# Altium schematic sheet ГРЛМ.468263.003_2 Э3.SchDoc
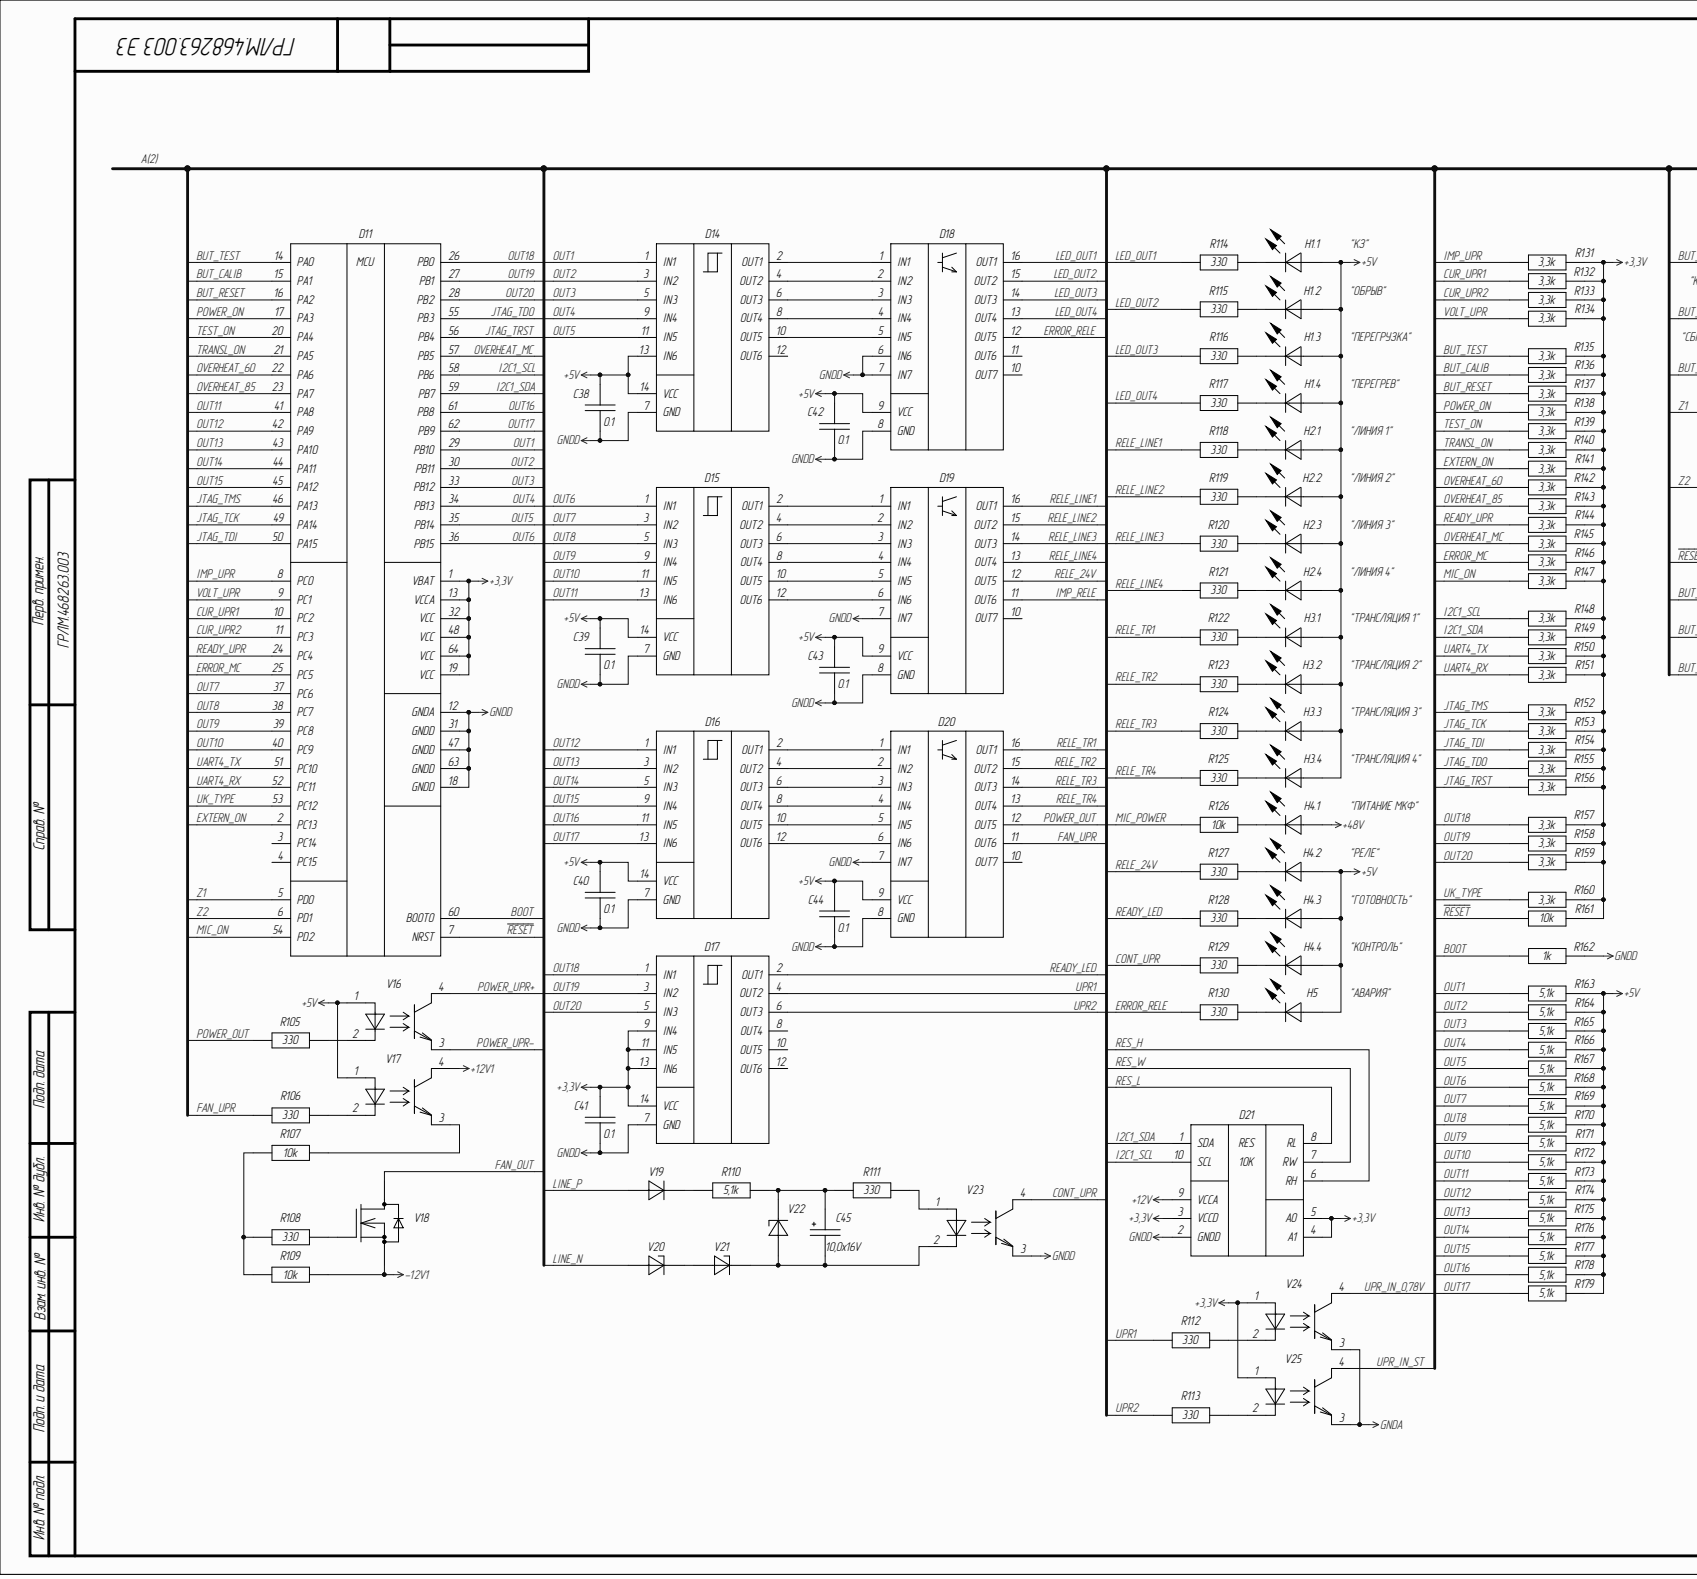
[diagram p0: ГРЛМ.468263.003_2 Э3.SchDoc, left]
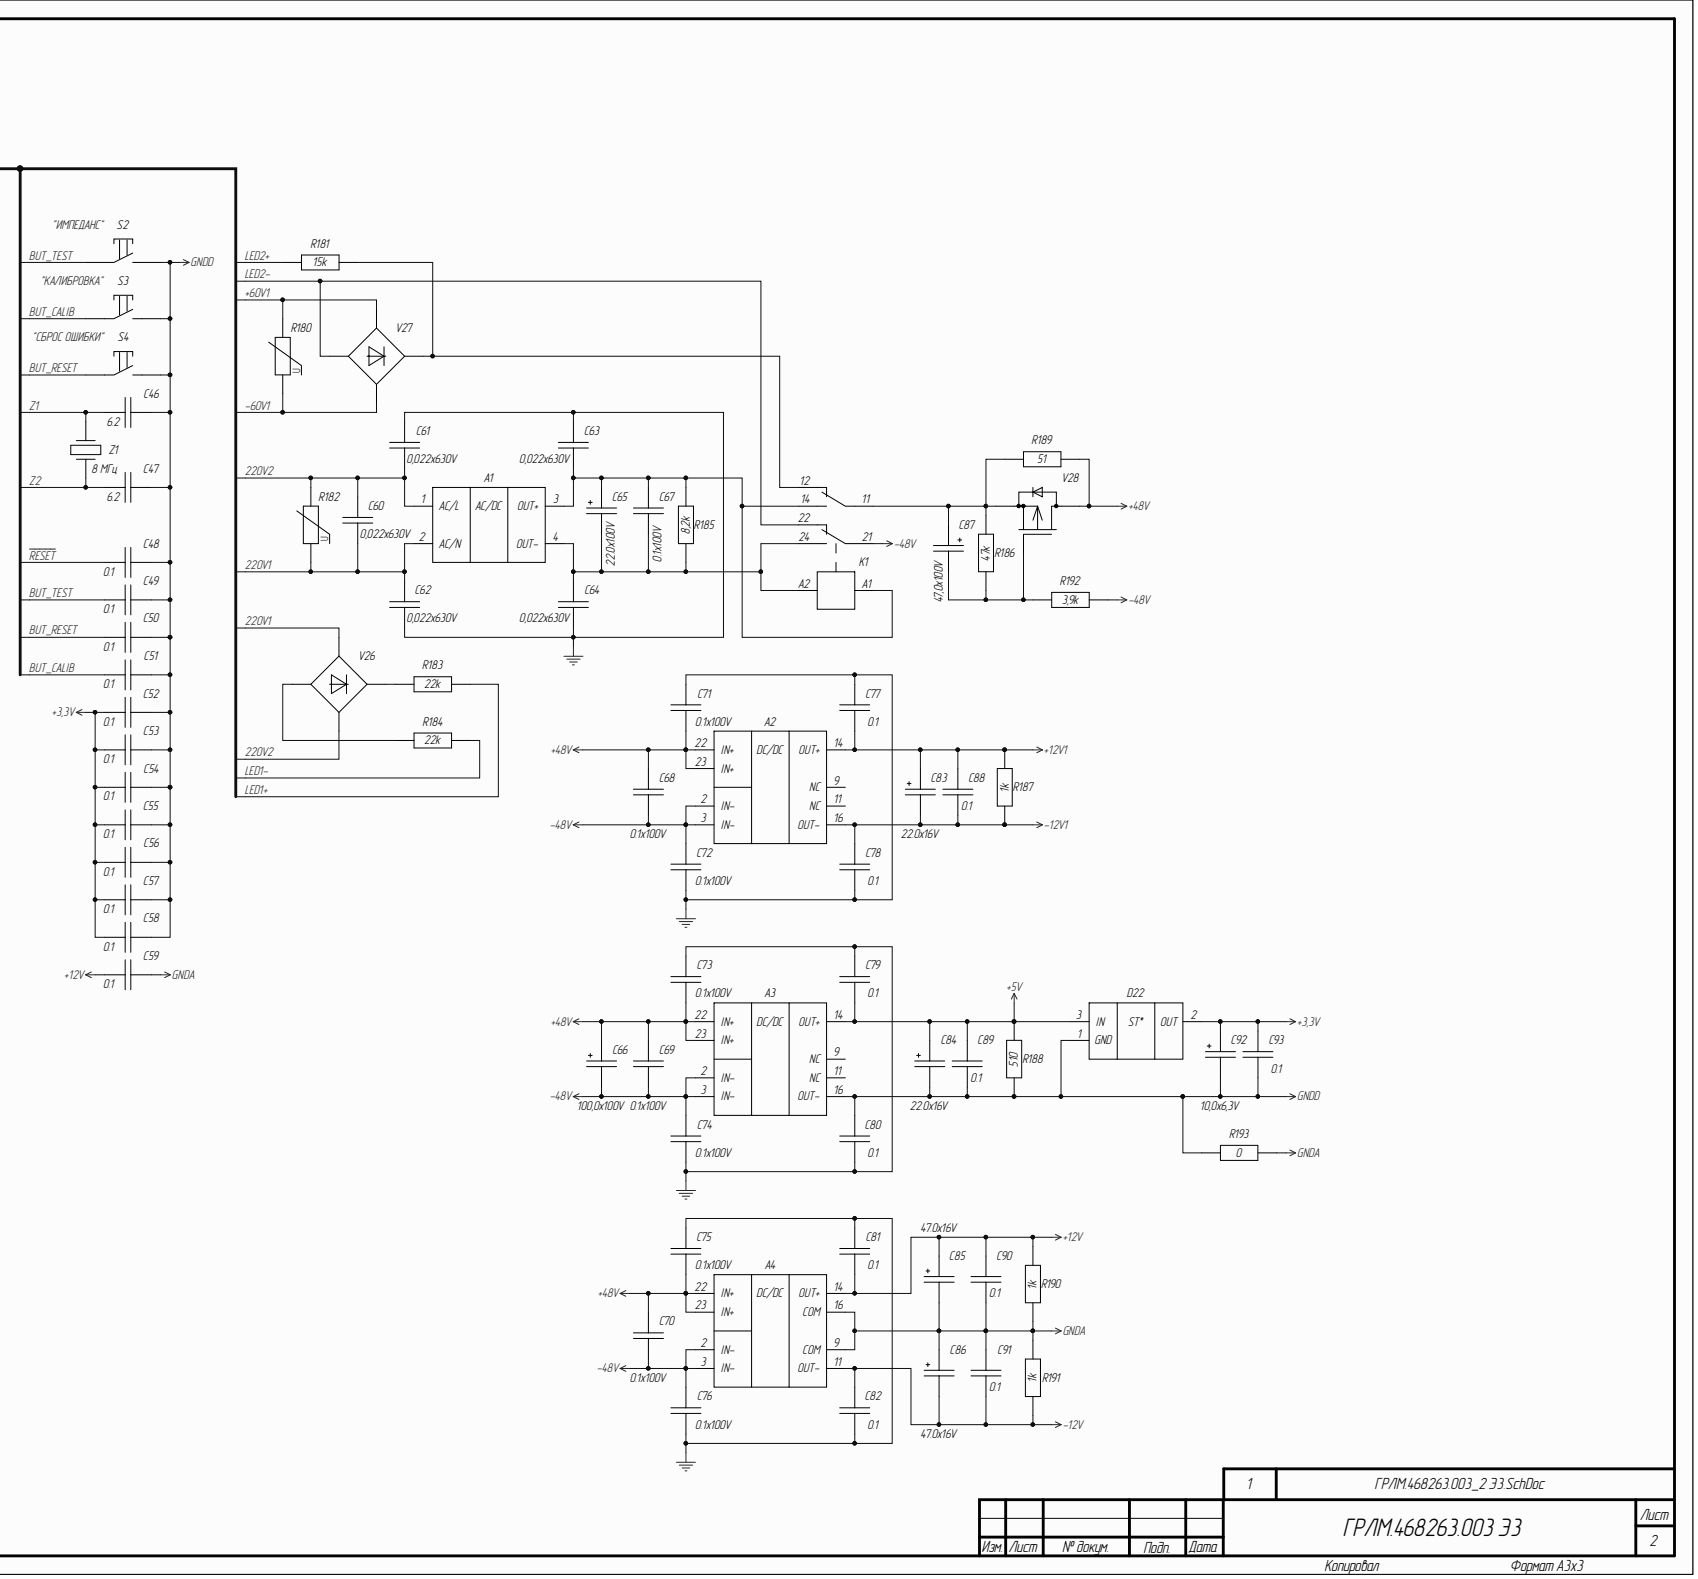
[diagram p1: ГРЛМ.468263.003_2 Э3.SchDoc, right]

## Parts
A1  IRM-30-48  [IRM-30-48]  (value not drawn)
A2  SCW12С-12  [SCW12С-12]  (value not drawn)
A3  SCW08С-05  [SCW08С-05]  (value not drawn)
A4  DCW08С-12  [DCW08С-12]  (value not drawn)
C38  value 0.1  [CAP0805]  (hidden comment: CERCAP_0805)
C39  value 0.1  [CAP0805]  (hidden comment: CERCAP_0805)
C40  value 0.1  [CAP0805]  (hidden comment: CERCAP_0805)
C41  value 0.1  [CAP0805]  (hidden comment: CERCAP_0805)
C42  value 0.1  [CAP0805]  (hidden comment: CERCAP_0805)
C43  value 0.1  [CAP0805]  (hidden comment: CERCAP_0805)
C44  value 0.1  [CAP0805]  (hidden comment: CERCAP_0805)
C45  value 10,0x16V  [CAP-T-A]  (hidden comment: TANCAP_A)
C46  value 6.2  [CAP0805]  (hidden comment: CERCAP_0805)
C47  value 6.2  [CAP0805]  (hidden comment: CERCAP_0805)
C48  value 0.1  [CAP0805]  (hidden comment: CERCAP_0805)
C49  value 0.1  [CAP0805]  (hidden comment: CERCAP_0805)
C50  value 0.1  [CAP0805]  (hidden comment: CERCAP_0805)
C51  value 0.1  [CAP0805]  (hidden comment: CERCAP_0805)
C52  value 0.1  [CAP0805]  (hidden comment: CERCAP_0805)
C53  value 0.1  [CAP0805]  (hidden comment: CERCAP_0805)
C54  value 0.1  [CAP0805]  (hidden comment: CERCAP_0805)
C55  value 0.1  [CAP0805]  (hidden comment: CERCAP_0805)
C56  value 0.1  [CAP0805]  (hidden comment: CERCAP_0805)
C57  value 0.1  [CAP0805]  (hidden comment: CERCAP_0805)
C58  value 0.1  [CAP0805]  (hidden comment: CERCAP_0805)
C59  value 0.1  [CAP0805]  (hidden comment: CERCAP_0805)
C60  value 0,022x630V  [CAP1812]  (hidden comment: CERCAP_1812)
C61  value 0,022x630V  [CAP1812]  (hidden comment: CERCAP_1812)
C62  value 0,022x630V  [CAP1812]  (hidden comment: CERCAP_1812)
C63  value 0,022x630V  [CAP1812]  (hidden comment: CERCAP_1812)
C64  value 0,022x630V  [CAP1812]  (hidden comment: CERCAP_1812)
C65  value 22.0x100V  [B41828A9226M006]  (hidden comment: RADCAP_10x13)
C66  value 100,0x100V  [B41851A9107M000]  (hidden comment: RADCAP_10x20)
C67  value 0.1х100V  [CAP1210]  (hidden comment: CERCAP_1210)
C68  value 0.1х100V  [CAP1210]  (hidden comment: CERCAP_1210)
C69  value 0.1х100V  [CAP1210]  (hidden comment: CERCAP_1210)
C70  value 0.1х100V  [CAP1210]  (hidden comment: CERCAP_1210)
C71  value 0.1х100V  [CAP1210]  (hidden comment: CERCAP_1210)
C72  value 0.1х100V  [CAP1210]  (hidden comment: CERCAP_1210)
C73  value 0.1х100V  [CAP1210]  (hidden comment: CERCAP_1210)
C74  value 0.1х100V  [CAP1210]  (hidden comment: CERCAP_1210)
C75  value 0.1х100V  [CAP1210]  (hidden comment: CERCAP_1210)
C76  value 0.1х100V  [CAP1210]  (hidden comment: CERCAP_1210)
C77  value 0.1  [CAP0805]  (hidden comment: CERCAP_0805)
C78  value 0.1  [CAP0805]  (hidden comment: CERCAP_0805)
C79  value 0.1  [CAP0805]  (hidden comment: CERCAP_0805)
C80  value 0.1  [CAP0805]  (hidden comment: CERCAP_0805)
C81  value 0.1  [CAP0805]  (hidden comment: CERCAP_0805)
C82  value 0.1  [CAP0805]  (hidden comment: CERCAP_0805)
C83  value 22.0x16V  [CAP-T-B]  (hidden comment: TANCAP_B)
C84  value 22.0x16V  [CAP-T-B]  (hidden comment: TANCAP_B)
C85  value 47.0x16V  [RС1H226M6L006VR]  (hidden comment: SMDCAP_6.3x5.4)
C86  value 47.0x16V  [RС1H226M6L006VR]  (hidden comment: SMDCAP_6.3x5.4)
C87  value 47,0х100V  [TKR470M2AG13M]  (hidden comment: RADCAP_10x16)
C88  value 0.1  [CAP0805]  (hidden comment: CERCAP_0805)
C89  value 0.1  [CAP0805]  (hidden comment: CERCAP_0805)
C90  value 0.1  [CAP0805]  (hidden comment: CERCAP_0805)
C91  value 0.1  [CAP0805]  (hidden comment: CERCAP_0805)
C92  value 10,0x6,3V  [CAP-T-A]  (hidden comment: TANCAP_A)
C93  value 0.1  [CAP0805]  (hidden comment: CERCAP_0805)
D11  STM32F103RCT6  [STM32F103RCT6]  (value not drawn)
D14  SN74HC14D  [SN74HC14D]  (value not drawn)
D15  SN74HC14D  [SN74HC14D]  (value not drawn)
D16  SN74HC14D  [SN74HC14D]  (value not drawn)
D17  SN74HC14D  [SN74HC14D]  (value not drawn)
D18  ULN2003ADR  [ULN2003ADR]  (value not drawn)
D19  ULN2003ADR  [ULN2003ADR]  (value not drawn)
D20  ULN2003ADR  [ULN2003ADR]  (value not drawn)
D21  CAT5132ZI-10-GT3  [CAT5132ZI-10-GT3]  (value not drawn)
D22  LM1117IDTX-3.3/NOPB  [LM1117IDTX]  (value not drawn)
H1  WP934SB/4SRD  [WP934SB/4SRD]  (value not drawn)  (parts .1,.2,.3,.4)
H2  WP934SB/4GD  [WP934SB/4GD]  (value not drawn)  (parts .1,.2,.3,.4)
H3  WP934SB/4GD  [WP934SB/4GD]  (value not drawn)  (parts .1,.2,.3,.4)
H4  WP934SB/4GD  [WP934SB/4GD]  (value not drawn)  (parts .1,.2,.3,.4)
H5  WP1384AD/ID  [WP1384AD/ID]  (value not drawn)
K1  RT424060  [RT424060]  (value not drawn)
R105  value 330  [RES0805]  (hidden comment: RES_0805)
R106  value 330  [RES0805]  (hidden comment: RES_0805)
R107  value 10k  [RES0805]  (hidden comment: RES_0805)
R108  value 330  [RES0805]  (hidden comment: RES_0805)
R109  value 10k  [RES0805]  (hidden comment: RES_0805)
R110  value 5,1k  [RES2512]  (hidden comment: RES_2512)
R111  value 330  [RES0805]  (hidden comment: RES_0805)
R112  value 330  [RES0805]  (hidden comment: RES_0805)
R113  value 330  [RES0805]  (hidden comment: RES_0805)
R114  value 330  [RES0805]  (hidden comment: RES_0805)
R115  value 330  [RES0805]  (hidden comment: RES_0805)
R116  value 330  [RES0805]  (hidden comment: RES_0805)
R117  value 330  [RES0805]  (hidden comment: RES_0805)
R118  value 330  [RES0805]  (hidden comment: RES_0805)
R119  value 330  [RES0805]  (hidden comment: RES_0805)
R120  value 330  [RES0805]  (hidden comment: RES_0805)
R121  value 330  [RES0805]  (hidden comment: RES_0805)
R122  value 330  [RES0805]  (hidden comment: RES_0805)
R123  value 330  [RES0805]  (hidden comment: RES_0805)
R124  value 330  [RES0805]  (hidden comment: RES_0805)
R125  value 330  [RES0805]  (hidden comment: RES_0805)
R126  value 10k  [RES1206]  (hidden comment: RES_1206)
R127  value 330  [RES0805]  (hidden comment: RES_0805)
R128  value 330  [RES0805]  (hidden comment: RES_0805)
R129  value 330  [RES0805]  (hidden comment: RES_0805)
R130  value 330  [RES0805]  (hidden comment: RES_0805)
R131  value 3,3k  [RES0805]  (hidden comment: RES_0805)
R132  value 3,3k  [RES0805]  (hidden comment: RES_0805)
R133  value 3,3k  [RES0805]  (hidden comment: RES_0805)
R134  value 3,3k  [RES0805]  (hidden comment: RES_0805)
R135  value 3,3k  [RES0805]  (hidden comment: RES_0805)
R136  value 3,3k  [RES0805]  (hidden comment: RES_0805)
R137  value 3,3k  [RES0805]  (hidden comment: RES_0805)
R138  value 3,3k  [RES0805]  (hidden comment: RES_0805)
R139  value 3,3k  [RES0805]  (hidden comment: RES_0805)
R140  value 3,3k  [RES0805]  (hidden comment: RES_0805)
R141  value 3,3k  [RES0805]  (hidden comment: RES_0805)
R142  value 3,3k  [RES0805]  (hidden comment: RES_0805)
R143  value 3,3k  [RES0805]  (hidden comment: RES_0805)
R144  value 3,3k  [RES0805]  (hidden comment: RES_0805)
R145  value 3,3k  [RES0805]  (hidden comment: RES_0805)
R146  value 3,3k  [RES0805]  (hidden comment: RES_0805)
R147  value 3,3k  [RES0805]  (hidden comment: RES_0805)
R148  value 3,3k  [RES0805]  (hidden comment: RES_0805)
R149  value 3,3k  [RES0805]  (hidden comment: RES_0805)
R150  value 3,3k  [RES0805]  (hidden comment: RES_0805)
R151  value 3,3k  [RES0805]  (hidden comment: RES_0805)
R152  value 3,3k  [RES0805]  (hidden comment: RES_0805)
R153  value 3,3k  [RES0805]  (hidden comment: RES_0805)
R154  value 3,3k  [RES0805]  (hidden comment: RES_0805)
R155  value 3,3k  [RES0805]  (hidden comment: RES_0805)
R156  value 3,3k  [RES0805]  (hidden comment: RES_0805)
R157  value 3,3k  [RES0805]  (hidden comment: RES_0805)
R158  value 3,3k  [RES0805]  (hidden comment: RES_0805)
R159  value 3,3k  [RES0805]  (hidden comment: RES_0805)
R160  value 3,3k  [RES0805]  (hidden comment: RES_0805)
R161  value 10k  [RES0805]  (hidden comment: RES_0805)
R162  value 1k  [RES0805]  (hidden comment: RES_0805)
R163  value 5,1k  [RES0805]  (hidden comment: RES_0805)
R164  value 5,1k  [RES0805]  (hidden comment: RES_0805)
R165  value 5,1k  [RES0805]  (hidden comment: RES_0805)
R166  value 5,1k  [RES0805]  (hidden comment: RES_0805)
R167  value 5,1k  [RES0805]  (hidden comment: RES_0805)
R168  value 5,1k  [RES0805]  (hidden comment: RES_0805)
R169  value 5,1k  [RES0805]  (hidden comment: RES_0805)
R170  value 5,1k  [RES0805]  (hidden comment: RES_0805)
R171  value 5,1k  [RES0805]  (hidden comment: RES_0805)
R172  value 5,1k  [RES0805]  (hidden comment: RES_0805)
R173  value 5,1k  [RES0805]  (hidden comment: RES_0805)
R174  value 5,1k  [RES0805]  (hidden comment: RES_0805)
R175  value 5,1k  [RES0805]  (hidden comment: RES_0805)
R176  value 5,1k  [RES0805]  (hidden comment: RES_0805)
R177  value 5,1k  [RES0805]  (hidden comment: RES_0805)
R178  value 5,1k  [RES0805]  (hidden comment: RES_0805)
R179  value 5,1k  [RES0805]  (hidden comment: RES_0805)
R180  V120ZA4P  [V120ZA4P]  (value not drawn)
R181  value 15k  [RES2512]  (hidden comment: RES_2512)
R182  B72210S0251K101  [B72210S0251K101]  (value not drawn)
R183  value 22k  [RES2512]  (hidden comment: RES_2512)
R184  value 22k  [RES2512]  (hidden comment: RES_2512)
R185  value 8,2k  [RES1210]  (hidden comment: RES_1210)
R186  value 47к  [RES0805]  (hidden comment: RES_0805)
R187  value 1k  [RES1206]  (hidden comment: RES_1206)
R188  value 510  [RES1206]  (hidden comment: RES_1206)
R189  value 51  [RES2010]  (hidden comment: RES_2010)
R190  value 1k  [RES1206]  (hidden comment: RES_1206)
R191  value 1k  [RES1206]  (hidden comment: RES_1206)
R192  value 3,9k  [RES0805]  (hidden comment: RES_0805)
R193  value 0  [RES1206]  (hidden comment: RES_1206)
S2  TS-A6PV-130  [TS-A6PV-130]  (value not drawn)
S3  TS-A6PV-130  [TS-A6PV-130]  (value not drawn)
S4  TS-A6PV-130  [TS-A6PV-130]  (value not drawn)
V16  HCPL-181-06AE  [HCPL-181]  (value not drawn)
V17  HCPL-181-06AE  [HCPL-181]  (value not drawn)
V18  IRLML2502TRPBF  [IRLML2502TRPBF]  (value not drawn)
V19  LL4007  [LL4007]  (value not drawn)
V20  BZV55C20  [BZV55]  (value not drawn)
V21  BZV55C20  [BZV55]  (value not drawn)
V22  BZV55C4V7  [BZV55]  (value not drawn)
V23  HCPL-181-06AE  [HCPL-181]  (value not drawn)
V24  HCPL-181-06AE  [HCPL-181]  (value not drawn)
V25  HCPL-181-06AE  [HCPL-181]  (value not drawn)
V26  MB6S  [MB6S]  (value not drawn)
V27  DB156S  [DB156S]  (value not drawn)
V28  IRFR5410TRLPBF  [IRFR5410TRLPBF]  (value not drawn)
Z1  value 8 МГц  [KX-3H +SMD]  (hidden comment: HC-49SM)

## Nets
+3,3V: C41.2 C52.1 C53.1 C54.1 C55.1 C56.1 C57.1 C58.1 C92.1 C93.2 D11.1(VBAT) D11.13(VCCA) D11.19(VCC) D11.32(VCC) D11.48(VCC) D11.64(VCC) D17.9(IN4) D17.11(IN5) D17.13(IN6) D17.14(VCC) D21.3(VCCD) D21.4(A1) D21.5(A0) D22.2 R131.1 R132.1 R133.1 R134.1 R135.1 R136.1 R137.1 R138.1 R139.1 R140.1 R141.1 R142.1 R143.1 R144.1 R145.1 R146.1 R147.1 R148.1 R149.1 R150.1 R151.1 R152.1 R153.1 R154.1 R155.1 R156.1 R157.1 R158.1 R159.1 R160.1 R161.1 V24.1 V25.1
+5V: A3.14(OUT+) C38.2 C39.2 C40.2 C42.2 C43.2 C44.2 C79.1 C84.1 C89.2 D14.13(IN6) D14.14(VCC) D15.14(VCC) D16.14(VCC) D18.9(VCC) D19.9(VCC) D20.9(VCC) D22.3 H1.2 H1.4 H1.6 H1.8 H2.2 H2.4 H2.6 H2.8 H3.2 H3.4 H3.6 H3.8 H4.4 H4.6 H4.8 H5.2 R163.1 R164.1 R165.1 R166.1 R167.1 R168.1 R169.1 R170.1 R171.1 R172.1 R173.1 R174.1 R175.1 R176.1 R177.1 R178.1 R179.1 R188.2 V16.1 V17.1
+12V: A4.14(OUT+) C59.1 C81.1 C85.1 C90.2 D21.9(VCCA) R190.2
+12V1: A2.14(OUT+) C77.1 C83.1 C88.2 R187.2 V17.4
+48V: A2.22(IN+) A2.23(IN+) A3.22(IN+) A3.23(IN+) A4.22(IN+) A4.23(IN+) C66.1 C68.2 C69.2 C70.2 C71.1 C73.1 C75.1 H4.2 R189.1 V28.4
-12V: A4.11(OUT-) C82.2 C86.2 C91.1 R191.1
-12V1: A2.16(OUT-) C78.2 C83.2 C88.1 R109.1 R187.1 V18.2
-48V: A2.2(IN-) A2.3(IN-) A3.2(IN-) A3.3(IN-) A4.2(IN-) A4.3(IN-) C66.2 C68.1 C69.1 C70.1 C72.2 C74.2 C76.2 K1.21 R192.2
GNDA: A4.9(COM) A4.16(COM) C59.2 C85.2 C86.1 C90.1 C91.2 R190.1 R191.2 R193.1 V24.3 V25.3
GNDD: A3.16(OUT-) C38.1 C39.1 C40.1 C41.1 C42.1 C43.1 C44.1 C46.2 C47.2 C48.2 C49.2 C50.2 C51.2 C52.2 C53.2 C54.2 C55.2 C56.2 C57.2 C58.2 C80.2 C84.2 C89.1 C92.2 C93.1 D11.12(GNDA) D11.18(GNDD) D11.31(GNDD) D11.47(GNDD) D11.63(GNDD) D14.7(GND) D15.7(GND) D16.7(GND) D17.7(GND) D18.6(IN6) D18.7(IN7) D18.8(GND) D19.7(IN7) D19.8(GND) D20.7(IN7) D20.8(GND) D21.2(GNDD) D22.1 R162.2 R188.1 R193.2 S2.2 S3.2 S4.2 V23.3
SHIELD: C61.2 C62.1 C63.2 C64.1 C71.2 C72.1 C73.2 C74.1 C75.2 C76.1 C77.2 C78.1 C79.2 C80.1 C81.2 C82.1
220V1: A1.2(AC/N) C60.1 C62.2 R182.1 V26.3
220V2: A1.1(AC/L) C60.2 C61.1 R182.2 V26.4
+60V1: R180.2 V27.3
-60V1: R180.1 V27.4
BOOT: D11.60(BOOT0) R162.1
BUT_CALIB: C51.1 D11.15(PA1) R136.2 S3.1
BUT_RESET: C50.1 D11.16(PA2) R137.2 S4.1
BUT_TEST: C49.1 D11.14(PA0) R135.2 S2.1
CONT_UPR: R129.2 V23.4
CUR_UPR1: D11.10(PC2) R132.2
CUR_UPR2: D11.11(PC3) R133.2
ERROR_MC: D11.25(PC5) R146.2
ERROR_RELE: D18.12(OUT5) R130.2
EXTERN_ON: D11.2(PC13) R141.2
FAN_OUT: V18.3
FAN_UPR: D20.11(OUT6) R106.1
I2C1_SCL: D11.58(PB6) D21.10(SCL) R148.2
I2C1_SDA: D11.59(PB7) D21.1(SDA) R149.2
IMP_RELE: D19.11(OUT6)
IMP_UPR: D11.8(PC0) R131.2
JTAG_TCK: D11.49(PA14) R153.2
JTAG_TDI: D11.50(PA15) R154.2
JTAG_TDO: D11.55(PB3) R155.2
JTAG_TMS: D11.46(PA13) R152.2
JTAG_TRST: D11.56(PB4) R156.2
LED1+: R183.2
LED1-: R184.2
LED2+: R181.1
LED2-: K1.22 V27.1
LED_OUT1: D18.16(OUT1) R114.2
LED_OUT2: D18.15(OUT2) R115.2
LED_OUT3: D18.14(OUT3) R116.2
LED_OUT4: D18.13(OUT4) R117.2
LINE_N: V20.2
LINE_P: V19.2
MIC_ON: D11.54(PD2) R147.2
MIC_POWER: R126.2
OUT1: D11.29(PB10) D14.1(IN1) R163.2
OUT2: D11.30(PB11) D14.3(IN2) R164.2
OUT3: D11.33(PB12) D14.5(IN3) R165.2
OUT4: D11.34(PB13) D14.9(IN4) R166.2
OUT5: D11.35(PB14) D14.11(IN5) R167.2
OUT6: D11.36(PB15) D15.1(IN1) R168.2
OUT7: D11.37(PC6) D15.3(IN2) R169.2
OUT8: D11.38(PC7) D15.5(IN3) R170.2
OUT9: D11.39(PC8) D15.9(IN4) R171.2
OUT10: D11.40(PC9) D15.11(IN5) R172.2
OUT11: D11.41(PA8) D15.13(IN6) R173.2
OUT12: D11.42(PA9) D16.1(IN1) R174.2
OUT13: D11.43(PA10) D16.3(IN2) R175.2
OUT14: D11.44(PA11) D16.5(IN3) R176.2
OUT15: D11.45(PA12) D16.9(IN4) R177.2
OUT16: D11.61(PB8) D16.11(IN5) R178.2
OUT17: D11.62(PB9) D16.13(IN6) R179.2
OUT18: D11.26(PB0) D17.1(IN1) R157.2
OUT19: D11.27(PB1) D17.3(IN2) R158.2
OUT20: D11.28(PB2) D17.5(IN3) R159.2
OVERHEAT_60: D11.22(PA6) R142.2
OVERHEAT_85: D11.23(PA7) R143.2
OVERHEAT_MC: D11.57(PB5) R145.2
POWER_ON: D11.17(PA3) R138.2
POWER_OUT: D20.12(OUT5) R105.1
POWER_UPR+: V16.4
POWER_UPR-: V16.3
~{RESET}: C48.1 D11.7(NRST) R161.2
READY_LED: D17.2(OUT1) R128.2
READY_UPR: D11.24(PC4) R144.2
RELE_24V: D19.12(OUT5) R127.2
RELE_LINE1: D19.16(OUT1) R118.2
RELE_LINE2: D19.15(OUT2) R119.2
RELE_LINE3: D19.14(OUT3) R120.2
RELE_LINE4: D19.13(OUT4) R121.2
RELE_TR1: D20.16(OUT1) R122.2
RELE_TR2: D20.15(OUT2) R123.2
RELE_TR3: D20.14(OUT3) R124.2
RELE_TR4: D20.13(OUT4) R125.2
RES_H: D21.6(RH)
RES_L: D21.8(RL)
RES_W: D21.7(RW)
TEST_ON: D11.20(PA4) R139.2
TRANSL_ON: D11.21(PA5) R140.2
UART4_RX: D11.52(PC11) R151.2
UART4_TX: D11.51(PC10) R150.2
UK_TYPE: D11.53(PC12) R160.2
UPR1: D17.4(OUT2) R112.1
UPR2: D17.6(OUT3) R113.1
UPR_IN_0,78V: V24.4
UPR_IN_ST: V25.4
VOLT_UPR: D11.9(PC1) R134.2
Z1: C46.1 D11.5(PD0) Z1.2
Z2: C47.1 D11.6(PD1) Z1.1
NetA1_3: A1.3(OUT+) C63.1 C65.1 C67.2 K1.14 K1.A1 R185.2
NetA1_4: A1.4(OUT-) C64.2 C65.2 C67.1 K1.24 K1.A2 R185.1
NetC45_1: C45.1 R110.2 R111.2 V22.1
NetC45_2: C45.2 V21.1 V22.2 V23.2
NetC87_1: C87.1 K1.11 R186.2 R189.2 V28.3
NetC87_2: C87.2 R186.1 R192.1 V28.1
NetD14_2: D14.2(OUT1) D18.1(IN1)
NetD14_4: D14.4(OUT2) D18.2(IN2)
NetD14_6: D14.6(OUT3) D18.3(IN3)
NetD14_8: D14.8(OUT4) D18.4(IN4)
NetD14_10: D14.10(OUT5) D18.5(IN5)
NetD15_2: D15.2(OUT1) D19.1(IN1)
NetD15_4: D15.4(OUT2) D19.2(IN2)
NetD15_6: D15.6(OUT3) D19.3(IN3)
NetD15_8: D15.8(OUT4) D19.4(IN4)
NetD15_10: D15.10(OUT5) D19.5(IN5)
NetD15_12: D15.12(OUT6) D19.6(IN6)
NetD16_2: D16.2(OUT1) D20.1(IN1)
NetD16_4: D16.4(OUT2) D20.2(IN2)
NetD16_6: D16.6(OUT3) D20.3(IN3)
NetD16_8: D16.8(OUT4) D20.4(IN4)
NetD16_10: D16.10(OUT5) D20.5(IN5)
NetD16_12: D16.12(OUT6) D20.6(IN6)
NetH1_1: H1.1 R114.1
NetH1_3: H1.3 R115.1
NetH1_5: H1.5 R116.1
NetH1_7: H1.7 R117.1
NetH2_1: H2.1 R118.1
NetH2_3: H2.3 R119.1
NetH2_5: H2.5 R120.1
NetH2_7: H2.7 R121.1
NetH3_1: H3.1 R122.1
NetH3_3: H3.3 R123.1
NetH3_5: H3.5 R124.1
NetH3_7: H3.7 R125.1
NetH4_1: H4.1 R126.1
NetH4_3: H4.3 R127.1
NetH4_5: H4.5 R128.1
NetH4_7: H4.7 R129.1
NetH5_1: H5.1 R130.1
NetK1_12: K1.12 R181.2 V27.2
NetR105_2: R105.2 V16.2
NetR106_2: R106.2 V17.2
NetR107_1: R107.1 R108.1 R109.2
NetR107_2: R107.2 V17.3
NetR108_2: R108.2 V18.1
NetR110_1: R110.1 V19.1
NetR111_1: R111.1 V23.1
NetR112_2: R112.2 V24.2
NetR113_2: R113.2 V25.2
NetR183_1: R183.1 V26.2
NetR184_1: R184.1 V26.1
NetV20_1: V20.1 V21.2
N00237:

Not connected: A2 9(NC) 11(NC); A3 9(NC) 11(NC); D11 3(PC14) 4(PC15); D14 12(OUT6); D17 8(OUT4) 10(OUT5) 12(OUT6); D18 10(OUT7) 11(OUT6); D19 10(OUT7); D20 10(OUT7)

## Library data of the parts
A1 = IRM-30-48: Модуль питания; Производитель: MEAN WELL; Component Kind: Standard; Library Name: Модули.SchLib
A2 = SCW12С-12: Модуль питания; Производитель: MEAN WELL; Component Kind: Standard; Library Name: Модули.SchLib
A3 = SCW08С-05: Модуль питания; Производитель: MEAN WELL; Component Kind: Standard; Library Name: Модули.SchLib
A4 = DCW08С-12: Модуль питания; Производитель: MEAN WELL; Component Kind: Standard; Library Name: Модули.SchLib
C38-C44 C48-C59 C77-C82 C88-C91 C93 = CERCAP_0805: Конденсатор керамический; Type: CC0805KPX7R9BB104; Артикул: 0805-0,1 мкФ±10%-50В-X7R; Производитель: YAGEO; Component Kind: Standard; Library Name: Конденсаторы.SchLib
C45 = TANCAP_A: Конденсатор танталовый; Type: TAJA106K016RNJ; Артикул: 10 мкФ±10%-16В, тип A; Производитель: AVX; Component Kind: Standard; Library Name: ГРЛМ.468363.001 Э3.SCHLIB
C46 C47 = CERCAP_0805: Конденсатор керамический; Type: C0805C629C5GACTU; Артикул: 0805-6,2 пФ±5%-50В-NP0; Производитель: YAGEO; Component Kind: Standard; Library Name: Конденсаторы.SchLib
C60-C64 = CERCAP_1812: Конденсатор керамический; Type: GRM43D7U2J223JW31L; Артикул: 1812-0,022 мкФ±5%-630В-U2J; Производитель: Murata; Component Kind: Standard; Library Name: Конденсаторы.SchLib
C65 = RADCAP_10x13: Конденсатор электролитический радиальный; Артикул: 22 мкФ±20%-100В, 8x12, RM5,0; Производитель: EPCOS; Component Kind: Standard; Type: B41828A9226M006; Library Name: Конденсаторы.SchLib
C66 = RADCAP_10x20: Конденсатор электролитический радиальный; Артикул: 100 мкФ±20%-100В, 10x20, RM5,0; Производитель: EPCOS; Component Kind: Standard; Type: B41851A9107M000; Library Name: Конденсаторы.SchLib
C67-C76 = CERCAP_1210: Конденсатор керамический; Type: CC1210KFX7R0BB104; Артикул: 1210-0,1 мкФ±10%-100В-X7R; Производитель: YAGEO; Component Kind: Standard; Library Name: Конденсаторы.SchLib
C83 C84 = TANCAP_B: Конденсатор танталовый; Type: TAJB226K016RNJ; Артикул: 22 мкФ±10%-16В, тип B; Производитель: AVX; Component Kind: Standard; Library Name: Конденсаторы.SchLib
C85 C86 = SMDCAP_6.3x5.4: Конденсатор электролитический SMD; Type: RС1C476M6L005VR; Артикул: 47 мкФ±10%-16В, 6,3x5,4; Производитель: AVX; Component Kind: Standard; Library Name: Конденсаторы.SchLib
C87 = RADCAP_10x16: Конденсатор электролитический радиальный; Артикул: 47 мкФ±20%-100В, 10x16, RM5,0; Производитель: Jamicon; Component Kind: Standard; Type: TKR470M2AG13M; Library Name: Конденсаторы.SchLib
C92 = TANCAP_A: Конденсатор танталовый; Type: TAJA106K006RNJ; Артикул: 10 мкФ±10%-6,3В, тип A; Производитель: AVX; Component Kind: Standard; Library Name: ГРЛМ.468389.001.SCHLIB
D11 = STM32F103RCT6: Микроконтроллер; Производитель: STMicroelectronics; Component Kind: Standard; Library Name: Микросхемы.SchLib
D14-D17 = SN74HC14D_1: 6 х НЕ (совмещ. изобр.); Производитель: Texas Instruments; Component Kind: Standard; Library Name: Микросхемы.SchLib
D18-D20 = ULN2003ADR: Транзисторы Дарлингтона; Производитель: Texas Instruments; Component Kind: Standard; Library Name: Микросхемы.SchLib
D21 = CAT5132ZI-10-GT3: Цифровой резистор 10к; Производитель: ON Semiconductor; Component Kind: Standard; Library Name: Микросхемы.SchLib
D22 = LM1117IDTX-3.3/NOPB: Стабилизатор 3,3В; Производитель: Texas Instruments; Component Kind: Standard; Library Name: Микросхемы.SchLib
H1 = WP934SB/4SRD: Светодиод счетверенный в корпусе; Производитель: Kingbright; Component Kind: Standard; Library Name: Устройства индикации.SchLib
H2-H4 = WP934SB/4GD: Светодиод счетверенный в корпусе; Производитель: Kingbright; Component Kind: Standard; Library Name: Устройства индикации.SchLib
H5 = WP1384AD/ID: Светодиод одинарный в корпусе красный; Производитель: Kingbright; Component Kind: Standard; Library Name: Устройства индикации.SchLib
K1 = RT424060: Реле DC 60V 8A; Производитель: Kingbright; Component Kind: Standard; Library Name: Реле.SchLib
R105 R106 R108 R111-R125 R127-R130 = RES_0805: Резистор SMD; Артикул: 0805-330 Ом±5%; Производитель: YAGEO; Component Kind: Standard; Type: RC0805JR-07330RL; Library Name: Резисторы.SchLib
R107 R109 R161 = RES_0805: Резистор SMD; Артикул: 0805-10 кОм±5%; Производитель: YAGEO; Component Kind: Standard; Type: RC0805JR-0710KL; Library Name: Резисторы.SchLib
R110 = RES_2512: Резистор SMD; Артикул: 2512-5,1 кОм±5%; Производитель: YAGEO; Component Kind: Standard; Type: RC2512JK-075K1L; Library Name: Резисторы.SchLib
R126 = RES_1206: Резистор SMD; Артикул: 1206-10 кОм±5%; Производитель: YAGEO; Component Kind: Standard; Type: RC1206JR-0710KL; Library Name: Резисторы.SchLib
R131-R160 = RES_0805: Резистор SMD; Артикул: 0805-3,3 кОм±5%; Производитель: YAGEO; Component Kind: Standard; Type: RC0805JR-073K3L; Library Name: Резисторы.SchLib
R162 = RES_0805: Резистор SMD; Артикул: 0805-1 кОм±5%; Производитель: YAGEO; Component Kind: Standard; Type: RC0805JR-071KL; Library Name: Резисторы.SchLib
R163-R179 = RES_0805: Резистор SMD; Артикул: 0805-5,1 кОм±5%; Производитель: YAGEO; Component Kind: Standard; Type: RC0805JR-075K1L; Library Name: Резисторы.SchLib
R180 = V120ZA4P: Варистор; Производитель: Littlefuse; Component Kind: Standard; Library Name: Резисторы.SchLib
R181 = RES_2512: Резистор SMD; Артикул: 2512-15 кОм±5%; Производитель: YAGEO; Component Kind: Standard; Type: RC2512JK-0715KL; Library Name: Резисторы.SchLib
R182 = B72210S0251K101: Варистор сетевой 250В 38Дж; Производитель: EPCOS; Component Kind: Standard; Library Name: Резисторы.SchLib
R183 R184 = RES_2512: Резистор SMD; Артикул: 2512-22 кОм±5%; Производитель: YAGEO; Component Kind: Standard; Type: RC2512JK-0722KL; Library Name: Резисторы.SchLib
R185 = RES_1210: Резистор SMD; Артикул: 1210-8,2 кОм±5%; Производитель: YAGEO; Component Kind: Standard; Type: RC1210JK-078K2L; Library Name: Резисторы.SchLib
R186 = RES_0805: Резистор SMD; Артикул: 0805-47 кОм±5%; Производитель: YAGEO; Component Kind: Standard; Type: RC0805JR-0747KL; Library Name: Резисторы.SchLib
R187 R190 R191 = RES_1206: Резистор SMD; Артикул: 1206-1 кОм±5%; Производитель: YAGEO; Component Kind: Standard; Type: RC1206JR-071KL; Library Name: Резисторы.SchLib
R188 = RES_1206: Резистор SMD; Артикул: 1206-510 Ом±5%; Производитель: YAGEO; Component Kind: Standard; Type: RC1206JR-07510RL; Library Name: Резисторы.SchLib
R189 = RES_2010: Резистор SMD; Артикул: 2010-51 Ом±5%; Производитель: YAGEO; Component Kind: Standard; Type: RC2010JR-0751RL; Library Name: Резисторы.SchLib
R192 = RES_0805: Резистор SMD; Артикул: 0805-3,9 кОм±5%; Производитель: YAGEO; Component Kind: Standard; Type: RC0805JR-073K9L; Library Name: Резисторы.SchLib
R193 = RES_1206: Резистор SMD; Артикул: 1206-0 Ом±5%; Производитель: YAGEO; Component Kind: Standard; Type: RC1206JR-070RL; Library Name: Резисторы.SchLib
S2-S4 = TS-A6PV-130: Кнопка тактовая; Производитель: Switronic; Component Kind: Standard; Library Name: Коммутационные изделия.SchLib
V16 V17 V23-V25 = HCPL-181-06AE: Оптопара; Производитель: Broadcom; Component Kind: Standard; Library Name: Микросхемы.SchLib
V18 = IRLML2502TRPBF: Транзистор N-MOSFET; Производитель: NXP; Component Kind: Standard; Library Name: Транзисторы.SchLib
V19 = LL4007: Диод выпрямительный; Производитель: Vishay; Component Kind: Standard; Library Name: Полупроводники.SchLib
V20 V21 = BZV55C20: Стабилитрон; Производитель: NXP; Component Kind: Standard; Library Name: Полупроводники.SchLib
V22 = BZV55C4V7: Стабилитрон; Производитель: NXP; Component Kind: Standard; Library Name: Полупроводники.SchLib
V26 = MB6S: Диодный мост; Производитель: ON Semiconductor; Component Kind: Standard; Library Name: Полупроводники.SchLib
V27 = DB156S: Диодный мост; Component Kind: Standard; Library Name: Полупроводники.SchLib
V28 = IRFR5410TRLPBF: Транзистор P-MOSFET; Component Kind: Standard; Library Name: Транзисторы.SchLib
Z1 = HC-49SM: Кварцевый резонатор; Артикул: KX-3HT HC-49/U8H 8 MHz±30ppm; Производитель: Geyer Electronic; Component Kind: Standard; Library Name: Резонаторы_Фильтры.SchLib
